# Altium schematic sheet 10_SFPX4.SchDoc
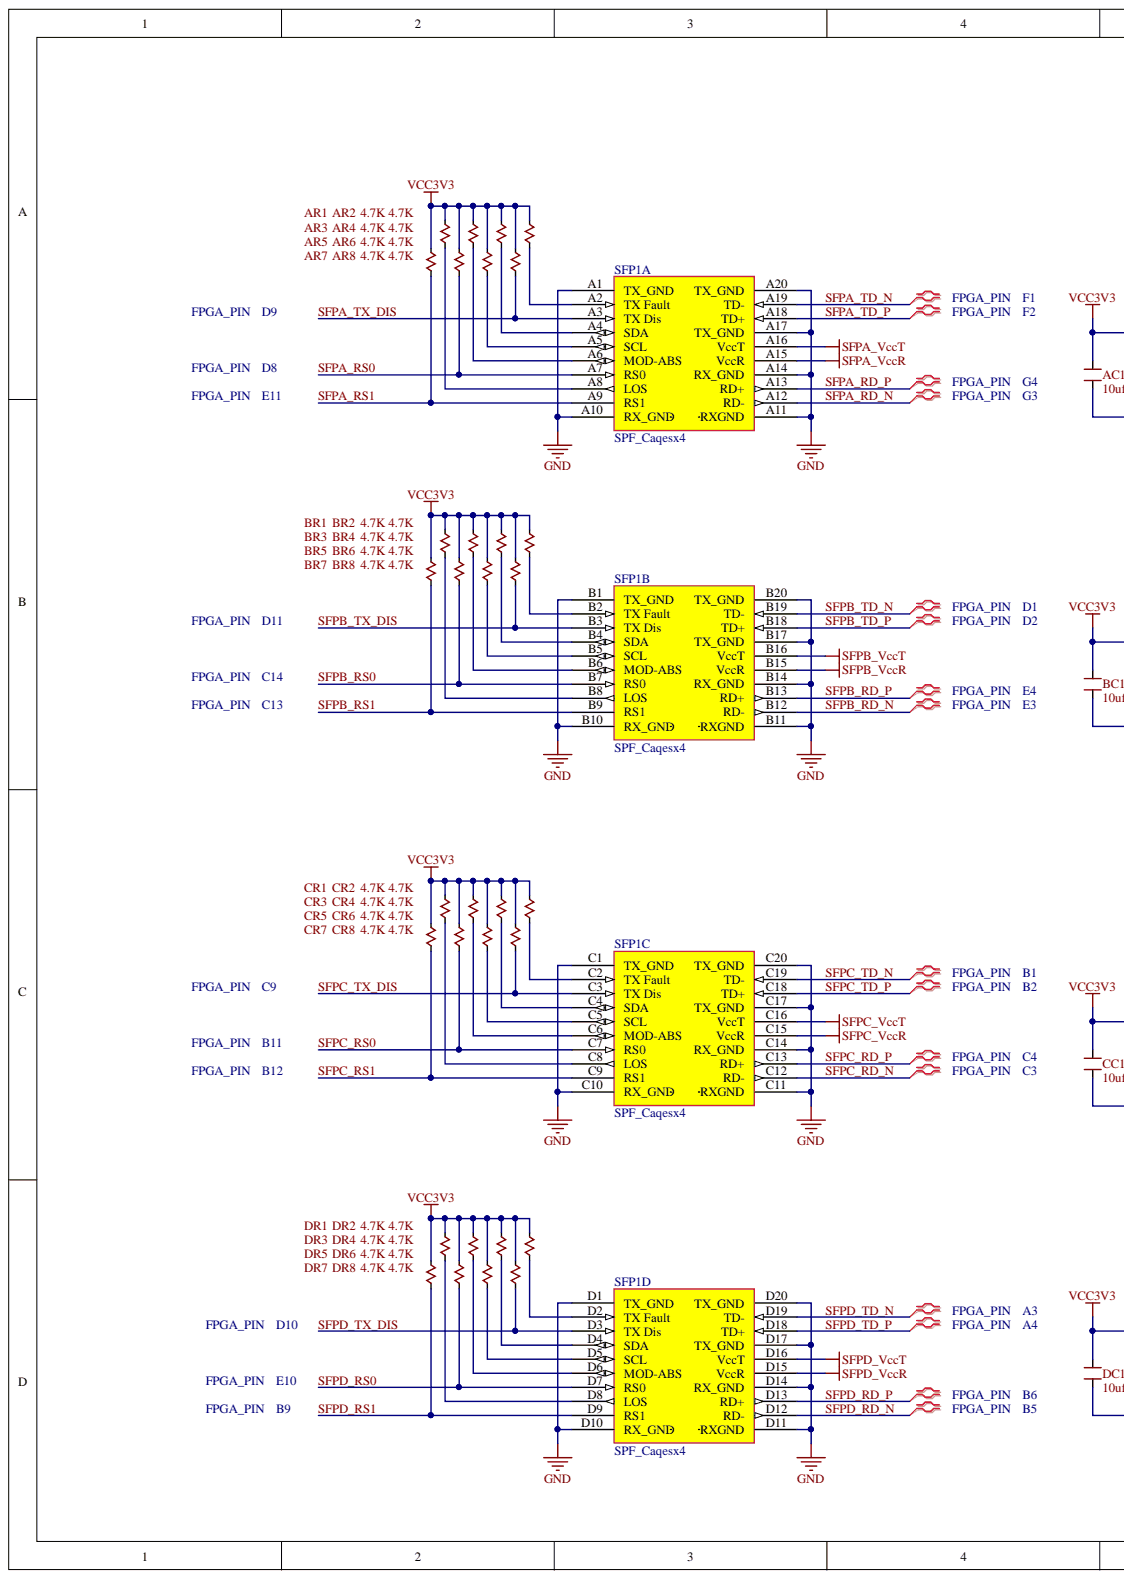
[diagram p0: 10_SFPX4.SchDoc, left]
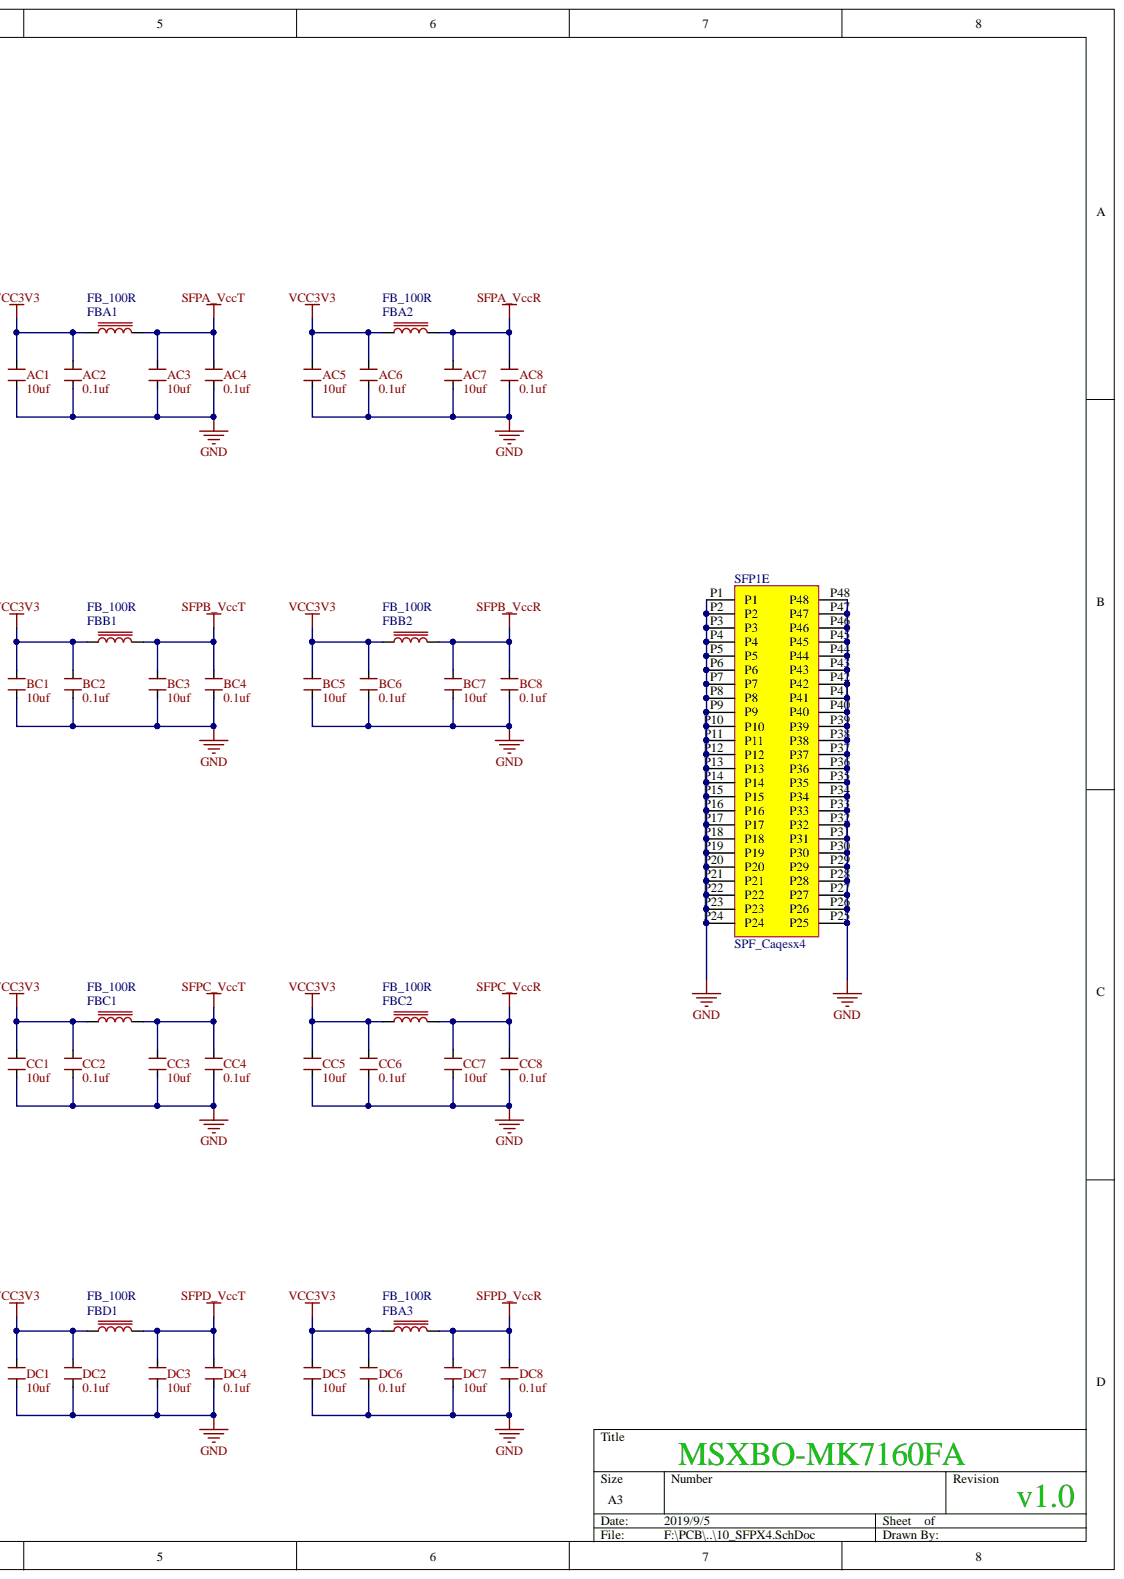
[diagram p1: 10_SFPX4.SchDoc, right]

## Parts
AC1  10uf  [CAP0603]
AC2  0.1uf  [CAP0402]
AC3  10uf  [CAP0603]
AC4  0.1uf  [CAP0402]
AC5  10uf  [CAP0603]
AC6  0.1uf  [CAP0402]
AC7  10uf  [CAP0603]
AC8  0.1uf  [CAP0402]
AR1  4.7K  [RES0402]
AR2  4.7K  [RES0402]
AR3  4.7K  [RES0402]
AR4  4.7K  [RES0402]
AR5  4.7K  [RES0402]
AR6  4.7K  [RES0402]
AR7  4.7K  [RES0402]
AR8  4.7K  [RES0402]
BC1  10uf  [CAP0603]
BC2  0.1uf  [CAP0402]
BC3  10uf  [CAP0603]
BC4  0.1uf  [CAP0402]
BC5  10uf  [CAP0603]
BC6  0.1uf  [CAP0402]
BC7  10uf  [CAP0603]
BC8  0.1uf  [CAP0402]
BR1  4.7K  [RES0402]
BR2  4.7K  [RES0402]
BR3  4.7K  [RES0402]
BR4  4.7K  [RES0402]
BR5  4.7K  [RES0402]
BR6  4.7K  [RES0402]
BR7  4.7K  [RES0402]
BR8  4.7K  [RES0402]
CC1  10uf  [CAP0603]
CC2  0.1uf  [CAP0402]
CC3  10uf  [CAP0603]
CC4  0.1uf  [CAP0402]
CC5  10uf  [CAP0603]
CC6  0.1uf  [CAP0402]
CC7  10uf  [CAP0603]
CC8  0.1uf  [CAP0402]
CR1  4.7K  [RES0402]
CR2  4.7K  [RES0402]
CR3  4.7K  [RES0402]
CR4  4.7K  [RES0402]
CR5  4.7K  [RES0402]
CR6  4.7K  [RES0402]
CR7  4.7K  [RES0402]
CR8  4.7K  [RES0402]
DC1  10uf  [CAP0603]
DC2  0.1uf  [CAP0402]
DC3  10uf  [CAP0603]
DC4  0.1uf  [CAP0402]
DC5  10uf  [CAP0603]
DC6  0.1uf  [CAP0402]
DC7  10uf  [CAP0603]
DC8  0.1uf  [CAP0402]
DR1  4.7K  [RES0402]
DR2  4.7K  [RES0402]
DR3  4.7K  [RES0402]
DR4  4.7K  [RES0402]
DR5  4.7K  [RES0402]
DR6  4.7K  [RES0402]
DR7  4.7K  [RES0402]
DR8  4.7K  [RES0402]
FBA1  FB_100R  [CAP0603]
FBA2  FB_100R  [CAP0603]
FBA3  FB_100R  [CAP0603]
FBB1  FB_100R  [CAP0603]
FBB2  FB_100R  [CAP0603]
FBC1  FB_100R  [CAP0603]
FBC2  FB_100R  [CAP0603]
FBD1  FB_100R  [CAP0603]
SFP1  SPF_Caqesx4  [SPF_Caqesx4]  (parts A,B,C,D,E)

## Nets
GND: AC1.2 AC2.2 AC3.2 AC4.2 AC5.2 AC6.2 AC7.2 AC8.2 BC1.2 BC2.2 BC3.2 BC4.2 BC5.2 BC6.2 BC7.2 BC8.2 CC1.2 CC2.2 CC3.2 CC4.2 CC5.2 CC6.2 CC7.2 CC8.2 DC1.2 DC2.2 DC3.2 DC4.2 DC5.2 DC6.2 DC7.2 DC8.2 SFP1.A1(TX_GND) SFP1.A10(RX_GND) SFP1.A11(RXGND) SFP1.A14(RX_GND) SFP1.A17(TX_GND) SFP1.A20(TX_GND) SFP1.B1(TX_GND) SFP1.B10(RX_GND) SFP1.B11(RXGND) SFP1.B14(RX_GND) SFP1.B17(TX_GND) SFP1.B20(TX_GND) SFP1.C1(TX_GND) SFP1.C10(RX_GND) SFP1.C11(RXGND) SFP1.C14(RX_GND) SFP1.C17(TX_GND) SFP1.C20(TX_GND) SFP1.D1(TX_GND) SFP1.D10(RX_GND) SFP1.D11(RXGND) SFP1.D14(RX_GND) SFP1.D17(TX_GND) SFP1.D20(TX_GND) SFP1.P1 SFP1.P2 SFP1.P3 SFP1.P4 SFP1.P5 SFP1.P6 SFP1.P7 SFP1.P8 SFP1.P9 SFP1.P10 SFP1.P11 SFP1.P12 SFP1.P13 SFP1.P14 SFP1.P15 SFP1.P16 SFP1.P17 SFP1.P18 SFP1.P19 SFP1.P20 SFP1.P21 SFP1.P22 SFP1.P23 SFP1.P24 SFP1.P25 SFP1.P26 SFP1.P27 SFP1.P28 SFP1.P29 SFP1.P30 SFP1.P31 SFP1.P32 SFP1.P33 SFP1.P34 SFP1.P35 SFP1.P36 SFP1.P37 SFP1.P38 SFP1.P39 SFP1.P40 SFP1.P41 SFP1.P42 SFP1.P43 SFP1.P44 SFP1.P45 SFP1.P46 SFP1.P47 SFP1.P48
SFPA_VCCR: AC7.1 AC8.1 FBA2.2 SFP1.A15(VccR)
SFPA_VCCT: AC3.1 AC4.1 FBA1.2 SFP1.A16(VccT)
SFPB_VCCR: BC7.1 BC8.1 FBB2.2 SFP1.B15(VccR)
SFPB_VCCT: BC3.1 BC4.1 FBB1.2 SFP1.B16(VccT)
SFPC_VCCR: CC7.1 CC8.1 FBC2.2 SFP1.C15(VccR)
SFPC_VCCT: CC3.1 CC4.1 FBC1.2 SFP1.C16(VccT)
SFPD_VCCR: DC7.1 DC8.1 FBA3.2 SFP1.D15(VccR)
SFPD_VCCT: DC3.1 DC4.1 FBD1.2 SFP1.D16(VccT)
VCC3V3: AC1.1 AC2.1 AC5.1 AC6.1 AR1.1 AR2.1 AR3.1 AR4.1 AR5.1 AR6.1 AR7.1 AR8.1 BC1.1 BC2.1 BC5.1 BC6.1 BR1.1 BR2.1 BR3.1 BR4.1 BR5.1 BR6.1 BR7.1 BR8.1 CC1.1 CC2.1 CC5.1 CC6.1 CR1.1 CR2.1 CR3.1 CR4.1 CR5.1 CR6.1 CR7.1 CR8.1 DC1.1 DC2.1 DC5.1 DC6.1 DR1.1 DR2.1 DR3.1 DR4.1 DR5.1 DR6.1 DR7.1 DR8.1 FBA1.1 FBA2.1 FBA3.1 FBB1.1 FBB2.1 FBC1.1 FBC2.1 FBD1.1
SFPA_RD_N: SFP1.A12(RD-)
SFPA_RD_P: SFP1.A13(RD+)
SFPA_RS0: AR5.2 SFP1.A7(RS0)
SFPA_RS1: AR1.2 SFP1.A9(RS1)
SFPA_TD_N: SFP1.A19(TD-)
SFPA_TD_P: SFP1.A18(TD+)
SFPA_TX_DIS: AR6.2 SFP1.A3(TX_Dis)
SFPB_RD_N: SFP1.B12(RD-)
SFPB_RD_P: SFP1.B13(RD+)
SFPB_RS0: BR5.2 SFP1.B7(RS0)
SFPB_RS1: BR1.2 SFP1.B9(RS1)
SFPB_TD_N: SFP1.B19(TD-)
SFPB_TD_P: SFP1.B18(TD+)
SFPB_TX_DIS: BR6.2 SFP1.B3(TX_Dis)
SFPC_RD_N: SFP1.C12(RD-)
SFPC_RD_P: SFP1.C13(RD+)
SFPC_RS0: CR5.2 SFP1.C7(RS0)
SFPC_RS1: CR1.2 SFP1.C9(RS1)
SFPC_TD_N: SFP1.C19(TD-)
SFPC_TD_P: SFP1.C18(TD+)
SFPC_TX_DIS: CR6.2 SFP1.C3(TX_Dis)
SFPD_RD_N: SFP1.D12(RD-)
SFPD_RD_P: SFP1.D13(RD+)
SFPD_RS0: DR5.2 SFP1.D7(RS0)
SFPD_RS1: DR1.2 SFP1.D9(RS1)
SFPD_TD_N: SFP1.D19(TD-)
SFPD_TD_P: SFP1.D18(TD+)
SFPD_TX_DIS: DR6.2 SFP1.D3(TX_Dis)
NetAR2_2: AR2.2 SFP1.A5(SCL)
NetAR3_2: AR3.2 SFP1.A8(LOS)
NetAR4_2: AR4.2 SFP1.A4(SDA)
NetAR7_2: AR7.2 SFP1.A6(MOD-ABS)
NetAR8_2: AR8.2 SFP1.A2(TX_Fault)
NetBR2_2: BR2.2 SFP1.B5(SCL)
NetBR3_2: BR3.2 SFP1.B8(LOS)
NetBR4_2: BR4.2 SFP1.B4(SDA)
NetBR7_2: BR7.2 SFP1.B6(MOD-ABS)
NetBR8_2: BR8.2 SFP1.B2(TX_Fault)
NetCR2_2: CR2.2 SFP1.C5(SCL)
NetCR3_2: CR3.2 SFP1.C8(LOS)
NetCR4_2: CR4.2 SFP1.C4(SDA)
NetCR7_2: CR7.2 SFP1.C6(MOD-ABS)
NetCR8_2: CR8.2 SFP1.C2(TX_Fault)
NetDR2_2: DR2.2 SFP1.D5(SCL)
NetDR3_2: DR3.2 SFP1.D8(LOS)
NetDR4_2: DR4.2 SFP1.D4(SDA)
NetDR7_2: DR7.2 SFP1.D6(MOD-ABS)
NetDR8_2: DR8.2 SFP1.D2(TX_Fault)
A3:
A4:
B1:
B2:
B5:
B6:
B9:
B11:
B12:
C3:
C4:
C9:
C13:
C14:
D1:
D2:
D8:
D9:
D10:
D11:
E3:
E4:
E10:
E11:
F1:
F2:
FPGA_PIN:
G3:
G4:

Not connected: SFP1 A21 A22

## Library data of the parts
AC1-AC8 BC1-BC8 CC1-CC8 DC1-DC8 = CAPACITOR: Generic Capacitor; Value: 10nF; Component Kind: Standard; Library Name: MiZ7020N_定制.IntLib
AR1-AR8 BR1-BR8 CR1-CR8 DR1-DR8 = RESISTOR: Generic Resistor; Value: 100; Component Kind: Standard; Library Name: MiZ7020N_定制.IntLib
FBA1-FBA3 FBB1 FBB2 FBC1 FBC2 FBD1 = Ferrite Bead: Generic Ferrite Bead; Value: 600; Component Kind: Standard; Library Name: MiZ7020N_定制.IntLib
SFP1 = SPF_Caqesx4: Component Kind: Standard; Library Name: M7Z100F20180320.IntLib
